# Altium schematic sheet 06.MPU-6050.SchDoc
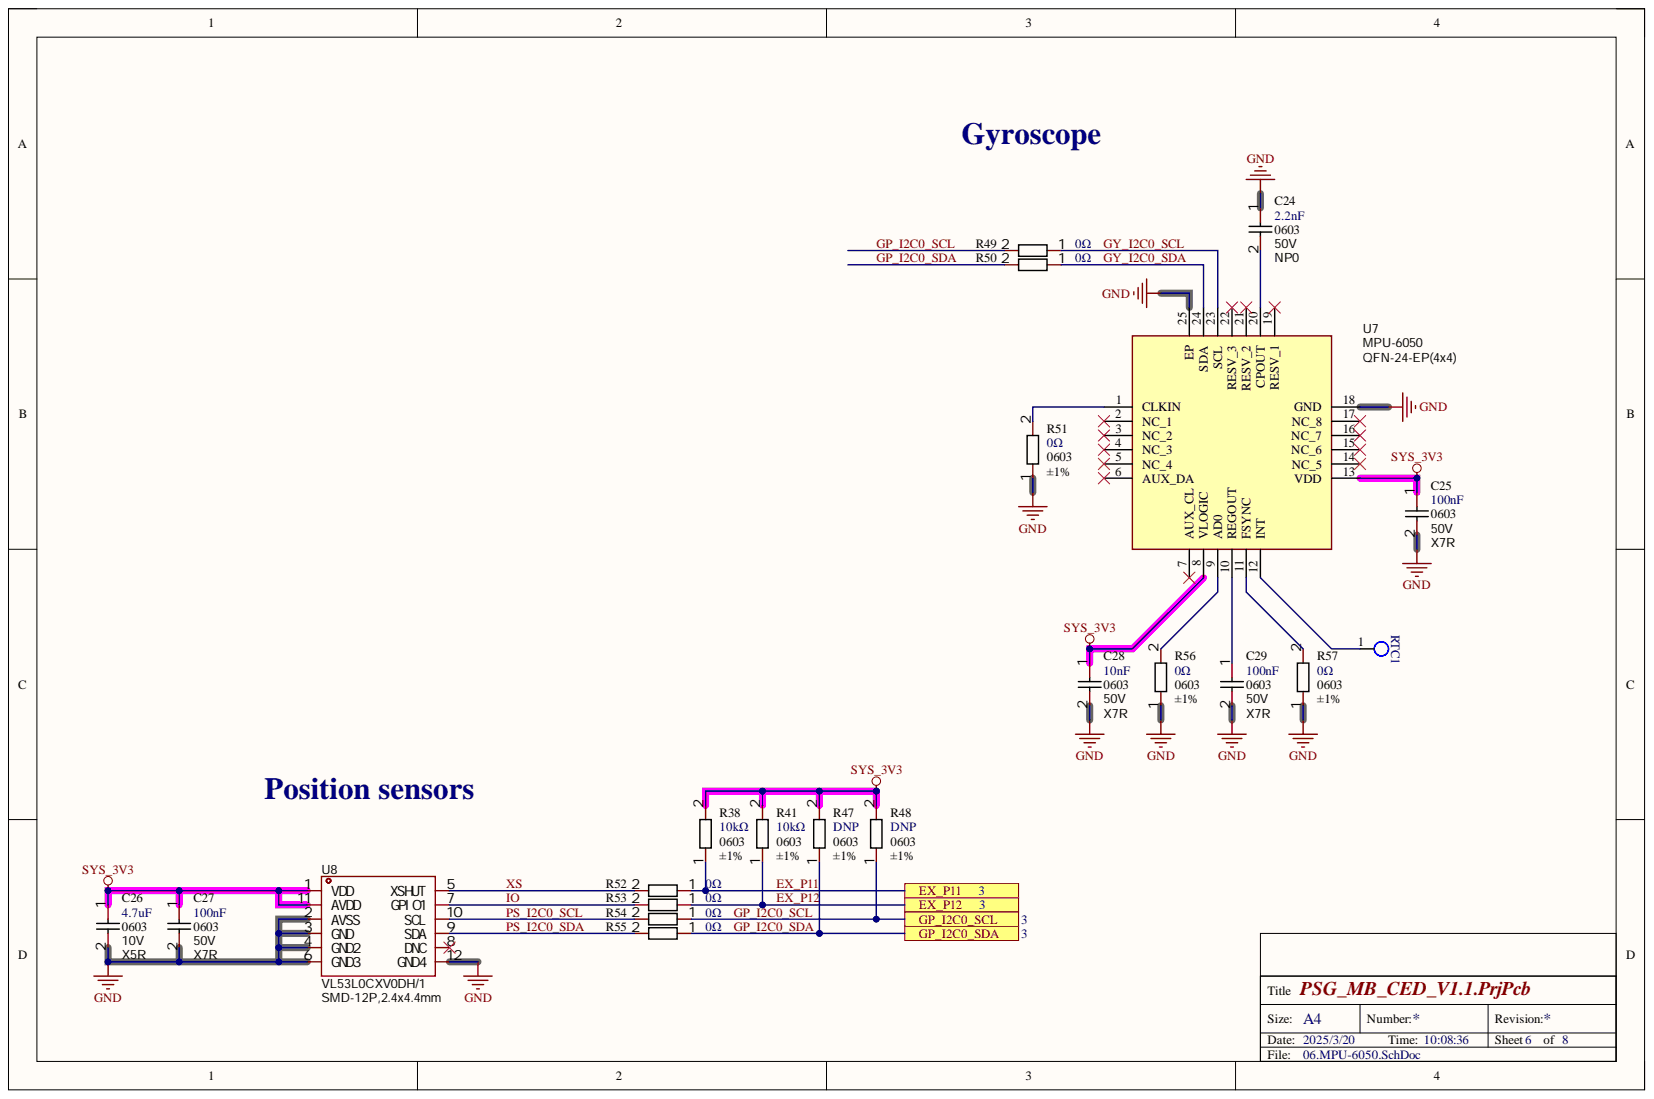
[diagram p0: 06.MPU-6050.SchDoc]

## Parts
C24  2.2nF  Supplier Footprint: 0603  Temperature Coefficient: NP0  Voltage Rated: 50V  [C0603]
C25  100nF  Supplier Footprint: 0603  Temperature Coefficient: X7R  Voltage Rated: 50V  [C0603]
C26  4.7uF  Supplier Footprint: 0603  Temperature Coefficient: X5R  Voltage Rated: 10V  [C0603]
C27  100nF  Supplier Footprint: 0603  Temperature Coefficient: X7R  Voltage Rated: 50V  [C0603]
C28  10nF  Supplier Footprint: 0603  Temperature Coefficient: X7R  Voltage Rated: 50V  [C0603]
C29  100nF  Supplier Footprint: 0603  Temperature Coefficient: X7R  Voltage Rated: 50V  [C0603]
R38  10kΩ  Supplier Footprint: 0603  Tolerance: ±1%  [R0603]
R41  10kΩ  Supplier Footprint: 0603  Tolerance: ±1%  [R0603]
R47  DNP  Supplier Footprint: 0603  Tolerance: ±1%  [R0603]
R48  DNP  Supplier Footprint: 0603  Tolerance: ±1%  [R0603]
R49  0Ω  [R0603]
R50  0Ω  [R0603]
R51  0Ω  Supplier Footprint: 0603  Tolerance: ±1%  [R0603]
R52  0Ω  [R0603]
R53  0Ω  [R0603]
R54  0Ω  [R0603]
R55  0Ω  [R0603]
R56  0Ω  Supplier Footprint: 0603  Tolerance: ±1%  [R0603]
R57  0Ω  Supplier Footprint: 0603  Tolerance: ±1%  [R0603]
RTC1  TESTPOINT-DIP  [PAD_0R70]  (value not drawn)
U7  MPU-6050  Supplier Footprint: QFN-24-EP(4x4)  [QFN-24_4R0X4R0X0R5]
U8  VL53L0CXV0DH/1  Supplier Footprint: SMD-12P,2.4x4.4mm  [OPTO-SMD_12P_VL53L0CXV0DH-1]

## Ports
EX_P11, EX_P12, GP_I2C0_SCL, GP_I2C0_SDA

## Nets
GND: C24.1 C25.2 C26.2 C27.2 C28.2 C29.2 R51.1 R56.1 R57.1 U7.18(GND) U7.25(EP) U8.2(AVSS) U8.3(GND) U8.4(GND2) U8.6(GND3) U8.12(GND4)
SYS_3V3: C25.1 C26.1 C27.1 C28.1 R38.2 R41.2 R47.2 R48.2 U7.8(VLOGIC) U7.13(VDD) U8.1(VDD) U8.11(AVDD)
EX_P11: R38.1 R52.1 ; port EX_P11
EX_P12: R41.1 R53.1 ; port EX_P12
GP_I2C0_SCL: R48.1 R49.2 R54.1 ; port GP_I2C0_SCL
GP_I2C0_SDA: R47.1 R50.2 R55.1 ; port GP_I2C0_SDA
GY_I2C0_SCL: R49.1 U7.23(SCL)
GY_I2C0_SDA: R50.1 U7.24(SDA)
IO: R53.2 U8.7(GPIO1)
PS_I2C0_SCL: R54.2 U8.10(SCL)
PS_I2C0_SDA: R55.2 U8.9(SDA)
XS: R52.2 U8.5(XSHUT)
NetC24_2: C24.2 U7.20(CPOUT)
NetC29_1: C29.1 U7.10(REGOUT)
NetR51_2: R51.2 U7.1(CLKIN)
NetR56_2: R56.2 U7.9(AD0)
NetR57_2: R57.2 U7.11(FSYNC)
NetRTC1_1: RTC1.1 U7.12(INT)

Not connected: U7 2(NC_1) 3(NC_2) 4(NC_3) 5(NC_4) 6(AUX_DA) 7(AUX_CL) 14(NC_5) 15(NC_6) 16(NC_7) 17(NC_8) 19(RESV_1) 21(RESV_2) 22(RESV_3); U8 8(DNC)

## Library data of the parts
C24 = CC0603JRNPO9BN120: Manufacturer: YAGEO(国巨); Add into BOM: yes; Device: CC0603JRNPO9BN222; Name: 贴片电容; Tolerance: ±5%; Component Kind: Standard; Convert to PCB: yes; Datasheet: https://atta.szlcsc.com/upload/public/pdf/source/20170914/C128857_1505359892143968080.pdf; JLCPCB Part Class: Extended Part; LCSC Part Name: 2.2nF ±5% 50V; Manufacturer Part: CC0603JRNPO9BN222; Supplier: LCSC; Supplier Part: C107043; Symbol: CC0603JRNPO9BN222; Value: 2.2nF
C25 C27 C29 = CC0603JRNPO9BN120: Manufacturer: YAGEO(国巨); Add into BOM: yes; Device: CC0603KRX7R9BB104; Name: 贴片电容; Tolerance: ±10%; Component Kind: Standard; Convert to PCB: yes; Datasheet: https://atta.szlcsc.com/upload/public/pdf/source/20180910/C282072_33301C7F631E79921B82A8DAC6BCC5B3.pdf; JLCPCB Part Class: Basic Part; LCSC Part Name: 100nF ±10% 50V; Manufacturer Part: CC0603KRX7R9BB104; Supplier: LCSC; Supplier Part: C14663; Symbol: CC0603KRX7R9BB104; Value: 100nF
C26 = CC0603JRNPO9BN120: Manufacturer: YAGEO(国巨); Add into BOM: yes; Device: CC0603KRX5R6BB475; Name: 贴片电容; Tolerance: ±10%; Component Kind: Standard; Convert to PCB: yes; Datasheet: https://atta.szlcsc.com/upload/public/pdf/source/20180326/C109456_C871681ED5BBA6E2441ECA2A13136DA0.pdf; JLCPCB Part Class: Extended Part; LCSC Part Name: 4.7uF ±10% 10V; Manufacturer Part: CC0603KRX5R6BB475; Supplier: LCSC; Supplier Part: C109456; Symbol: CC0603KRX5R6BB475; Value: 4.7uF
C28 = CC0603JRNPO9BN120: Manufacturer: YAGEO(国巨); Add into BOM: yes; Device: CC0603KRX7R9BB103; Name: 贴片电容; Tolerance: ±10%; Component Kind: Standard; Convert to PCB: yes; Datasheet: https://atta.szlcsc.com/upload/public/pdf/source/20181008/C309510_22F155564471FAF6A489F7D68F34234E.pdf; JLCPCB Part Class: Extended Part; LCSC Part Name: 10nF ±10% 50V; Manufacturer Part: CC0603KRX7R9BB103; Supplier: LCSC; Supplier Part: C100042; Symbol: CC0603KRX7R9BB103; Value: 10nF
R38 R41 R47 R48 = 0603WAF1003T5E: Manufacturer: YAGEO(国巨); Add into BOM: yes; Device: RC0603FR-0710KL; Name: 贴片电阻; Overload Voltage (Max): 75V; Power(Watts): 100mW; Temperature Coefficient: ±100ppm/℃; Component Kind: Standard; Convert to PCB: yes; Datasheet: https://atta.szlcsc.com/upload/public/pdf/source/20180927/C295883_E8D83085EA81BD7DE0B2B681138C15EE.pdf; JLCPCB Part Class: Extended Part; LCSC Part Name: 厚膜电阻 10kΩ ±1% 100mW; Manufacturer Part: RC0603FR-0710KL; Operating Temperature Range: -55℃~+155℃; Supplier: LCSC; Supplier Part: C98220; Symbol: RC0603FR-0710KL; Type: 厚膜电阻
  R38 R41: Value: 10kΩ
  R47 R48: Value: DNP
R49-R57 = 0603WAF1003T5E: Manufacturer: YAGEO(国巨); Add into BOM: yes; Device: RC0603FR-070RL; Name: 贴片电阻; Overload Voltage (Max): 75V; Power(Watts): 100mW; Component Kind: Standard; Convert to PCB: yes; Datasheet: https://atta.szlcsc.com/upload/public/pdf/source/20180316/70C0E2BDAE7FCC62C531A5A58D202EE1.pdf; JLCPCB Part Class: Extended Part; LCSC Part Name: 厚膜电阻 0Ω ±1% 100mW; Manufacturer Part: RC0603FR-070RL; Operating Temperature Range: -55℃~+155℃; Supplier: LCSC; Supplier Part: C100044; Symbol: RC0603FR-070RL; Temperature Coefficient: -; Type: 厚膜电阻; Value: 0Ω
RTC1 = TESTPOINT-DIP: PAD; Part_Number: TP-DIP; Part_Type: TEST; ID: 4; Page_Name: 11-CPU POWER; Parameter: 独立过孔式测试点; PCB DECAL: TP100D60; Source_Path: \\DSERVER.ARTMEM.COM\AIC\DEPT\SD\SHARE\CADENCE CIS\LIBRARY\OLB\OTHERS.OLB; Value: TEST; Component Kind: Standard
U7 = MPU-6050: Manufacturer: TDK InvenSense(应美盛); Add into BOM: yes; Sensor Type: 加速度计陀螺仪; Symbol: MPU-6050_C24112; Component Kind: Standard; Convert to PCB: yes; Datasheet: https://atta.szlcsc.com/upload/public/pdf/source/20140425/1457707046159.pdf; JLCPCB Part Class: Extended Part; LCSC Part Name: MPU-6050; Library Name: MPU-6050_C241121_MPU-6050_C24112.SCHLIB; Manufacturer Part: MPU-6050; Operating Temperature: -40℃~+85℃; PCB3D: QFN-24_L4.0-W4.0-P0.50-BL-EP2.7; Supplier: LCSC; Supplier Part: C24112; Value: MPU-6050
U8 = VL53L0CXV0DH/1: Manufacturer: ST(意法半导体); Add into BOM: yes; Iinearity Range: 200cm; Component Kind: Standard; Convert to PCB: yes; Datasheet: https://atta.szlcsc.com/upload/public/pdf/source/20161226/1482720164293.pdf; JLCPCB Part Class: Extended Part; LCSC Part Name: VL53L0CXV0DH/1; Manufacturer Part: VL53L0CXV0DH/1; PCB3D: OPTO-SMD_12P_VL53L0CXV0DH-1; Supplier: LCSC; Supplier Part: C91199; Symbol: VL53L0CXV0DH/1; Value: VL53L0CXV0DH/1
